# Altium schematic sheet ESP32-S3核心板.SchDoc(ESP32-S3核心板)
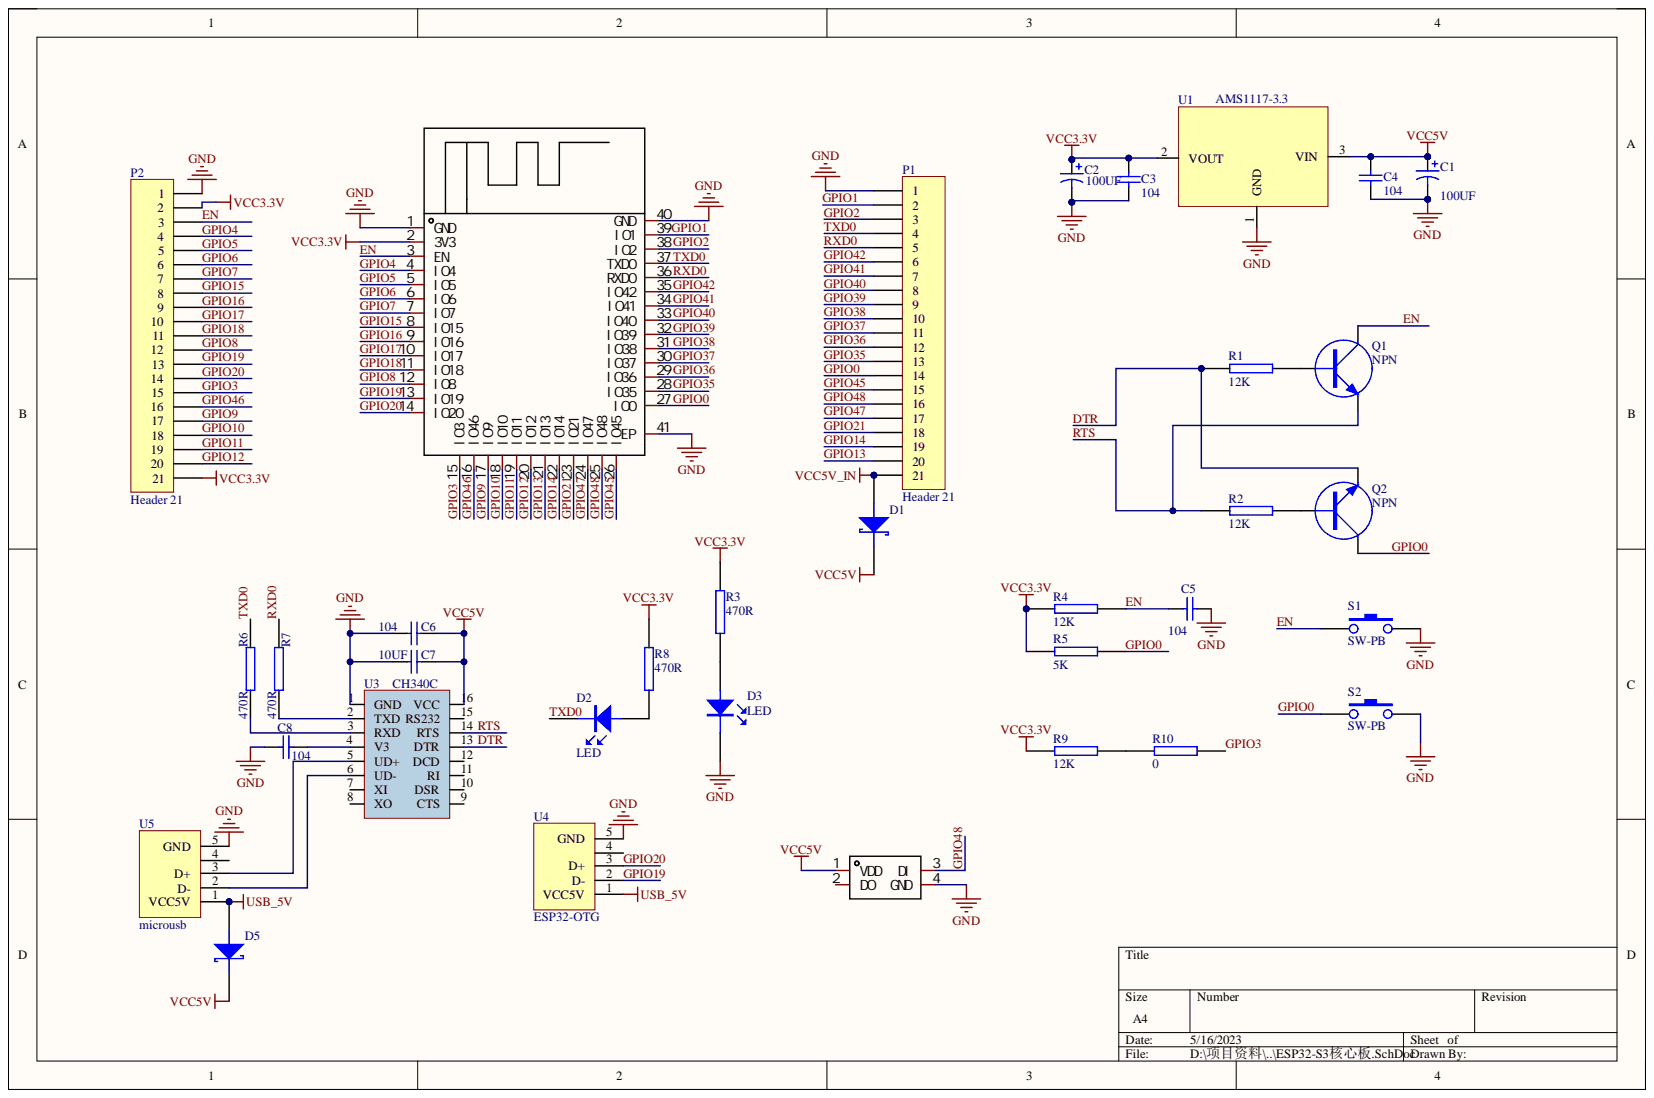
[diagram p0: ESP32-S3核心板.SchDoc]

## Parts
C1  value 100UF  [1206]
C2  100UF  [钽电容J107]
C3  104  [C0402]
C4  104  [C0402]
C5  104  [C0402]
C6  104  [C0402]
C7  value 10UF  [1206]  (hidden comment: Cap)
C8  104  [C0402]
D1  (no value)  [D0603]
D2  LED  [0603D]
D3  LED  [0603D]
D4  XL-5050RGBC-WS2812B  [LED-SMD_L5.0-W5.0-P3.20-LS5.4-TL]  (value not drawn)
D5  (no value)  [D0603]
P1  Header 21  [HDR1X21]
P2  Header 21  [HDR1X21]
Q1  NPN  [SOT-23]
Q2  NPN  [SOT-23]
R1  12K  [R0402]
R2  12K  [R0402]
R3  470R  [R0402]
R4  12K  [R0402]
R5  5K  [R0402]
R6  470R  [R0402]
R7  470R  [R0402]
R8  470R  [R0402]
R9  12K  [R0402]
R10  0  [R0402]
S1  SW-PB  [button]
S2  SW-PB  [button]
U1  AMS1117-3.3  [SOT223]
U2  ESP32-S3-WROOM-1-N8  [ESP32-S3 W1]  (value not drawn)
U3  CH340C  [SO-16_M]
U4  ESP32-OTG  [USB_T]
U5  microusb  [USB_T]

## Nets
GND: C1.2 C2.2 C3.1 C4.2 C5.1 C6.1 C7.1 C8.1 D3.K D4.4(GND) P1.1 P2.1 S1.2 S2.2 U1.1 U2.1(GND) U2.40(GND) U2.41(EP) U3.1(GND) U4.5(GND) U5.5(GND)
USB_5V: D5.1 U4.1(VCC5V) U5.1(VCC5V)
VCC3.3V: C2.1 C3.2 P2.2 P2.21 R3.2 R4.1 R5.1 R8.2 R9.1 U1.2 U2.2(3V3)
VCC5V: C1.1 C4.1 C6.2 C7.2 D1.2 D4.1(VDD) D5.2 U1.3 U3.16(VCC)
VCC5V_IN: D1.1 P1.21
DTR: Q2.1 R1.1 U3.13(DTR)
EN: C5.2 P2.3 Q1.3 R4.2 S1.1 U2.3(EN)
GPIO0: P1.14 Q2.3 R5.2 S2.1 U2.27(IO0)
GPIO1: P1.2 U2.39(IO1)
GPIO2: P1.3 U2.38(IO2)
GPIO3: P2.15 R10.2 U2.15(IO3)
GPIO4: P2.4 U2.4(IO4)
GPIO5: P2.5 U2.5(IO5)
GPIO6: P2.6 U2.6(IO6)
GPIO7: P2.7 U2.7(IO7)
GPIO8: P2.12 U2.12(IO8)
GPIO9: P2.17 U2.17(IO9)
GPIO10: P2.18 U2.18(IO10)
GPIO11: P2.19 U2.19(IO11)
GPIO12: P2.20 U2.20(IO12)
GPIO13: P1.20 U2.21(IO13)
GPIO14: P1.19 U2.22(IO14)
GPIO15: P2.8 U2.8(IO15)
GPIO16: P2.9 U2.9(IO16)
GPIO17: P2.10 U2.10(IO17)
GPIO18: P2.11 U2.11(IO18)
GPIO19: P2.13 U2.13(IO19) U4.2(D-)
GPIO20: P2.14 U2.14(IO20) U4.3(D+)
GPIO21: P1.18 U2.23(IO21)
GPIO35: P1.13 U2.28(IO35)
GPIO36: P1.12 U2.29(IO36)
GPIO37: P1.11 U2.30(IO37)
GPIO38: P1.10 U2.31(IO38)
GPIO39: P1.9 U2.32(IO39)
GPIO40: P1.8 U2.33(IO40)
GPIO41: P1.7 U2.34(IO41)
GPIO42: P1.6 U2.35(IO42)
GPIO45: P1.15 U2.26(IO45)
GPIO46: P2.16 U2.16(IO46)
GPIO47: P1.17 U2.24(IO47)
GPIO48: D4.3(DI) P1.16 U2.25(IO48)
RTS: Q1.1 R2.1 U3.14(RTS)
RXD0: P1.5 R7.2 U2.36(RXD0)
TXD0: D2.K P1.4 R6.2 U2.37(TXD0)
NetC8_2: C8.2 U3.4(V3)
NetD2_A: D2.A R8.1
NetD3_A: D3.A R3.1
NetQ1_2: Q1.2 R1.2
NetQ2_2: Q2.2 R2.2
NetR6_1: R6.1 U3.3(RXD)
NetR7_1: R7.1 U3.2(TXD)
NetR9_2: R9.2 R10.1
NetU3_5: U3.5(UD+) U5.3(D+)
NetU3_6: U3.6(UD-) U5.2(D-)

Not connected: D4 2(DO); U3 7(XI) 8(XO) 9(CTS) 10(DSR) 11(RI) 12(DCD) 15(RS232); U4 4; U5 4

## Library data of the parts
C1 C2 = Cap Pol1: Polarized Capacitor (Radial); Component Kind: Standard; LatestRevisionDate: 17-Jul-2002; LatestRevisionNote: Re-released for DXP Platform.; Library Name: Miscellaneous Devices.IntLib; PackageDescription: Polarized Capacitor (Radial); 2 Leads; PackageReference: RB7.6-15; Published: 8-Jun-2000; Signal Integrity: Cap Pol; Simulation: CAP; Publisher: Altium Limited
  C2: Value: 100UF
C3-C8 = Cap: Capacitor; Component Kind: Standard; LatestRevisionDate: 17-Jul-2002; LatestRevisionNote: Re-released for DXP Platform.; Library Name: Miscellaneous Devices.IntLib; PackageDescription: Radial Cap, Thru-Hole; 2 Leads; 0.3 in Pin Spacing; PackageReference: RAD-0.3; Published: 8-Jun-2000; Signal Integrity: Cap; Simulation: CAP; Publisher: Altium Limited
  C3-C6 C8: Value: 104
D1 D5 = DIODE SCHOTTKY: Schottky Diode; Component Kind: Standard; Library Name: Miscellaneous Devices.SCHLIB
D4 = XL-5050RGBC-WS2812B: Manufacturer: XINGLIGHT(成兴光); Add into BOM: yes; Emitted Color: RGB三色; Lens Color: 无色透明; Mounting Sytle: 正贴; Peak Wavelength: 625nm；470nm；530nm; Viewing Angle: 120°; Color Temperature: -; Component Kind: Standard; Convert to PCB: yes; Datasheet: https://atta.szlcsc.com/upload/public/pdf/source/20230111/86AAD2096485A2E5BCAB883FF8CBE6FD.pdf; Device: XL-5050RGBC-WS2812B; Diode Configuration: -; Dominant Wavelength: -; Forward Current: -; JLCPCB Part Class: Extended Part; LCSC Part Name: RGB三色 正贴 幻彩; Library Name: ESP32-S3.SchLib; Manufacturer Part: XL-5050RGBC-WS2812B; Name: XL-5050RGBC-WS2812B; Operating Temperature: -30℃~+70℃; PCB3D: LED-SMD_L5.0-W5.0-H1.5-P3.20-LS5.4-TL; Power Dissipation: -; Supplier: LCSC; Supplier Footprint: SMD5050; Supplier Part: C2843785; Symbol: XL-5050RGBC-WS2812B
P1 P2 = Header 21: Header, 20-Pin; Component Kind: Standard; LatestRevisionDate: 17-Jul-2002; LatestRevisionNote: Re-released for DXP Platform.; Library Name: ESP32-S3.SchLib; Signal Integrity: Connector; Publisher: Altium Limited
Q1 Q2 = NPN: NPN Transistor; Component Kind: Standard; Library Name: Miscellaneous Devices.SCHLIB
R1-R10 = RES2: Component Kind: Standard; Library Name: 常用1.SchLib
U2 = ESP32-S3-WROOM-1-N8: Manufacturer: ESPRESSIF(乐鑫); Add into BOM: yes; Component Kind: Standard; Convert to PCB: yes; Datasheet: https://atta.szlcsc.com/upload/public/pdf/source/20221026/9A44C6421221D672346AAE72DF9F8146.pdf; Device: ESP32-S3-WROOM-1-N8; JLCPCB Part Class: Extended Part; LCSC Part Name: ESP32-S3-WROOM-1-N8; Library Name: ESP32-S3.SchLib; Manufacturer Part: ESP32-S3-WROOM-1-N8; Name: ESP32-S3-WROOM-1-N8; PCB3D: WIFIM-SMD_ESP32-S3-WROOM-1-N8; Supplier: LCSC; Supplier Footprint: SMD,18x25.5mm; Supplier Part: C2913198; Symbol: ESP32-S3-WROOM-1-N8
U3 = CH340: Component Kind: Standard; Library Name: TLC521（光耦）.SchLib
U4 = microusb: Component Kind: Standard; Library Name: GY32-B1.SchLib
D2 D3 = LED: Component Kind: Standard; Library Name: Miscellaneous Devices.SCHLIB
S1 S2 = SW-PB: Component Kind: Standard; Library Name: Miscellaneous Devices.SCHLIB
U1 = AMS1117-3.3: Component Kind: Standard; Library Name: GY32-B1.SchLib
U5 = microusb: Component Kind: Standard; Library Name: GY32-B1.SchLib
